# Altium schematic sheet 8051_IO.SchDoc(8051_IO)
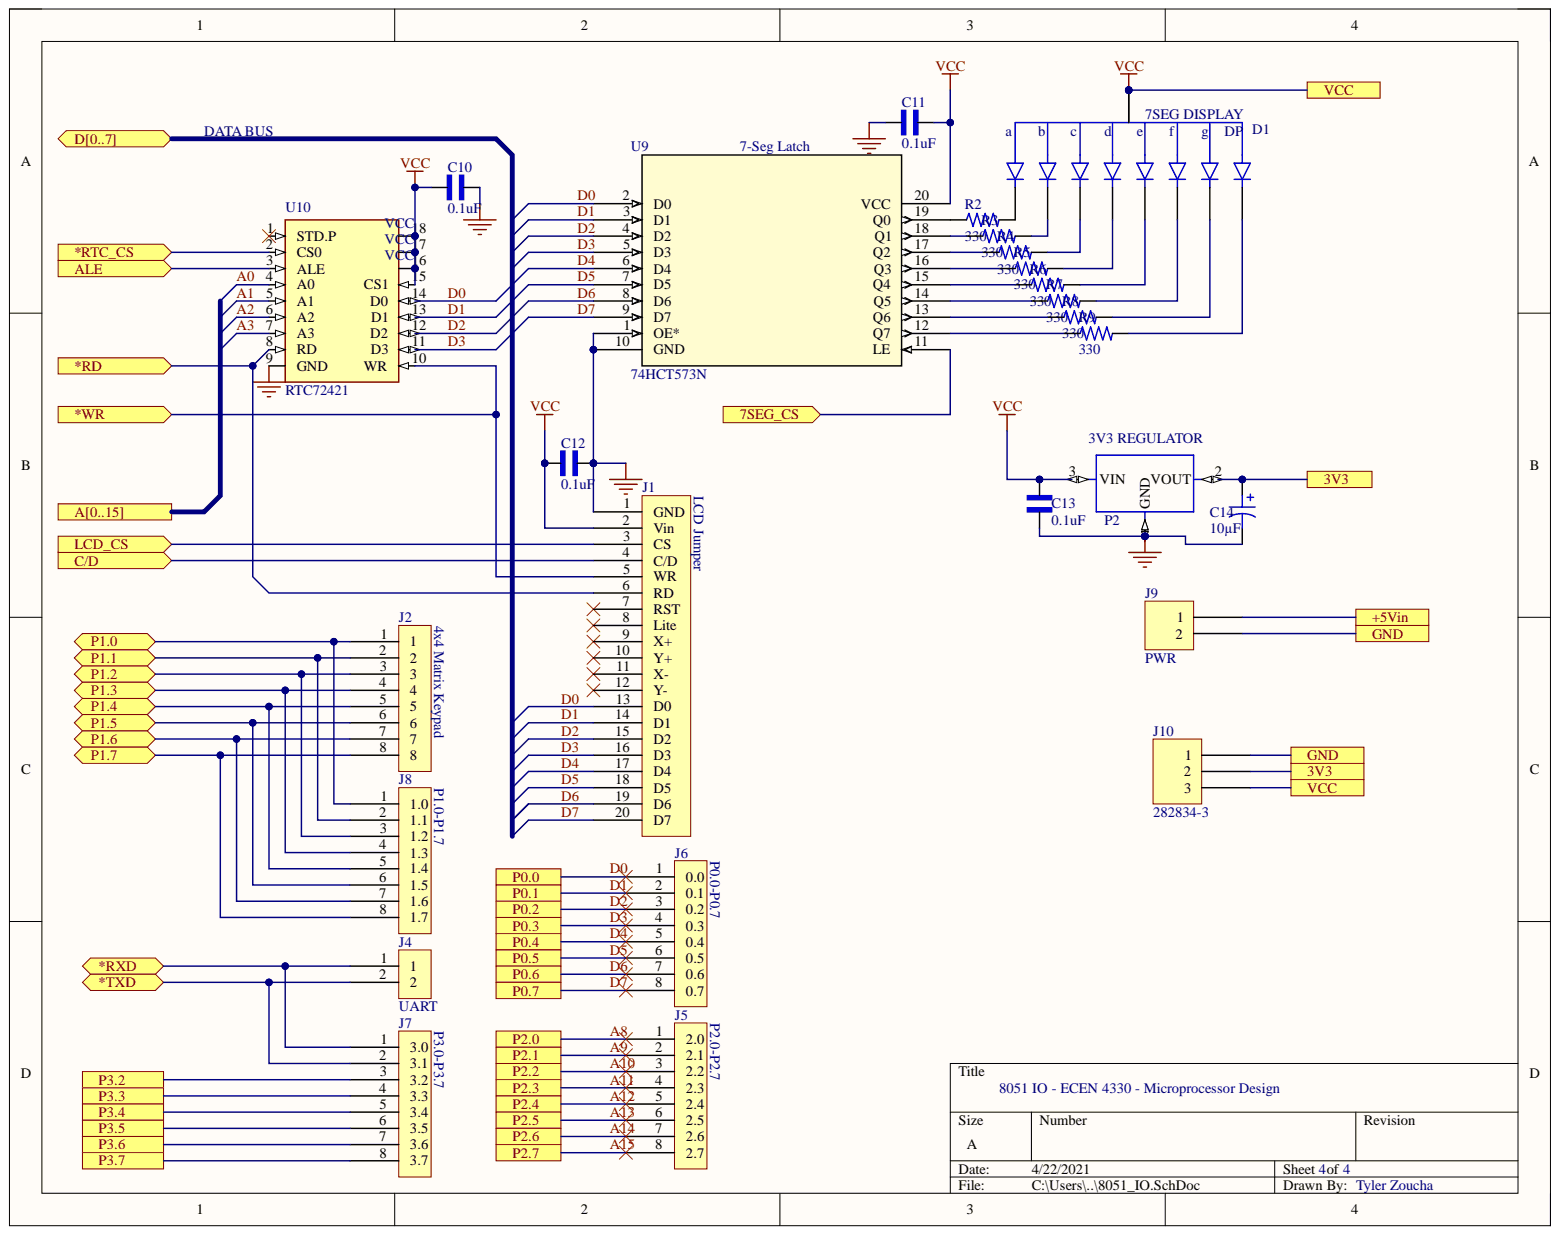
[diagram p0: 8051_IO.SchDoc]

## Parts
C10  0.1uF  [CAP_RDER73A681K2M1H03A]
C11  0.1uF  [CAP_RDER73A681K2M1H03A]
C12  0.1uF  [CAP_RDER73A681K2M1H03A]
C13  0.1uF  [CAP_RDER73A681K2M1H03A]
C14  10µF  [CAP TH ALUM ELEC D5.00mm S2.50mm H12.50mm]
D1  SA56-11SRWA  [DISPLAY_SA56-11SRWA]  (value not drawn)
J1  LCD Jumper  [20pinfemale_SIP]
J2  4x4 Matrix Keypad  [8pinheader_female]
J4  UART  [2pinheader_male]
J5  P2.0-P2.7  [8pinheader_female]
J6  P0.0-P0.7  [8pinheader_female]
J7  P3.0-P3.7  [8pinheader_female]
J8  P1.0-P1.7  [8pinheader_female]
J9  PWR  [TE 282834-2]
J10  282834-3  [TE 282834-3]
P2  PMIC-LD1117V33(TO-220)  [TO-220-3]  (value not drawn)
R2  330  [TO508P1060X500X1900-2]
R3  330  [TO508P1060X500X1900-2]
R4  330  [TO508P1060X500X1900-2]
R5  330  [TO508P1060X500X1900-2]
R6  330  [TO508P1060X500X1900-2]
R7  330  [TO508P1060X500X1900-2]
R8  330  [TO508P1060X500X1900-2]
R9  330  [TO508P1060X500X1900-2]
U9  74HCT573N  [SOT146-1_NEX]
U10  RTC72421  [DIL18]

## Ports
3V3, 7SEG_CS, *RD, *RTC_CS, *RXD, *TXD, *WR, +5Vin, A0, A1, A2, A3, A4, A5, A6, A7, A8, A9, A10, A11, A12, A13, A14, A15, A[0..15], ALE, C/D, D0, D1, D2, D3, D4, D5, D6, D7, D[0..7], GND, LCD_CS, P0.0, P0.1, P0.2, P0.3, P0.4, P0.5, P0.6, P0.7, P1.0, P1.1, P1.2, P1.3, P1.4, P1.5, P1.6, P1.7, P2.0, P2.1, P2.2, P2.3, P2.4, P2.5, P2.6, P2.7, P3.2, P3.3, P3.4, P3.5, P3.6, P3.7, VCC

## Nets
3V3: C14.1 J10.2 P2.2(VOUT) ; port 3V3
7SEG_CS: U9.11(LE) ; port 7SEG_CS
*RD: J1.6(RD) U10.8(RD) ; port *RD
*RTC_CS: U10.2(CS0) ; port *RTC_CS
*RXD: J4.1 J7.1(3.0) ; port *RXD
*TXD: J4.2 J7.2(3.1) ; port *TXD
*WR: J1.5(WR) U10.10(WR) ; port *WR
+5Vin: J9.1 ; port +5Vin
A0: U10.4(A0) ; port A[0..15]  [also labelled ]
A1: U10.5(A1) ; port A[0..15]  [also labelled ]
A2: U10.6(A2) ; port A[0..15]  [also labelled ]
A3: U10.7(A3) ; port A[0..15]  [also labelled ]
A8: J5.1(2.0) ; port P2.0
A9: J5.2(2.1) ; port P2.1
A10: J5.3(2.2) ; port P2.2
A11: J5.4(2.3) ; port P2.3
A12: J5.5(2.4) ; port P2.4
A13: J5.6(2.5) ; port P2.5
A14: J5.7(2.6) ; port P2.6
A15: J5.8(2.7) ; port P2.7
ALE: U10.3(ALE) ; port ALE
C/D: J1.4(C/D) ; port C/D
D0: J1.13(D0) J6.1(0.0) U9.2(D0) U10.14(D0) ; port D[0..7], port P0.0  [also labelled ]
D1: J1.14(D1) J6.2(0.1) U9.3(D1) U10.13(D1) ; port D[0..7], port P0.1  [also labelled ]
D2: J1.15(D2) J6.3(0.2) U9.4(D2) U10.12(D2) ; port D[0..7], port P0.2  [also labelled ]
D3: J1.16(D3) J6.4(0.3) U9.5(D3) U10.11(D3) ; port D[0..7], port P0.3  [also labelled ]
D4: J1.17(D4) J6.5(0.4) U9.6(D4) ; port D[0..7], port P0.4  [also labelled ]
D5: J1.18(D5) J6.6(0.5) U9.7(D5) ; port D[0..7], port P0.5  [also labelled ]
D6: J1.19(D6) J6.7(0.6) U9.8(D6) ; port D[0..7], port P0.6  [also labelled ]
D7: J1.20(D7) J6.8(0.7) U9.9(D7) ; port D[0..7], port P0.7  [also labelled ]
GND: J9.2 J10.1 ; port GND
LCD_CS: J1.3(CS) ; port LCD_CS
P1.0: J2.1 J8.1(1.0) ; port P1.0
P1.1: J2.2 J8.2(1.1) ; port P1.1
P1.2: J2.3 J8.3(1.2) ; port P1.2
P1.3: J2.4 J8.4(1.3) ; port P1.3
P1.4: J2.5 J8.5(1.4) ; port P1.4
P1.5: J2.6 J8.6(1.5) ; port P1.5
P1.6: J2.7 J8.7(1.6) ; port P1.6
P1.7: J2.8 J8.8(1.7) ; port P1.7
P3.2: J7.3(3.2) ; port P3.2
P3.3: J7.4(3.3) ; port P3.3
P3.4: J7.5(3.4) ; port P3.4
P3.5: J7.6(3.5) ; port P3.5
P3.6: J7.7(3.6) ; port P3.6
P3.7: J7.8(3.7) ; port P3.7
VCC: C10.1 C11.2 C12.1 C13.2 D1.3 D1.8 J1.2(Vin) J10.3 P2.3(VIN) U9.20(VCC) U10.15(CS1) U10.16 U10.17 U10.18 ; port VCC
NetC10_2: C10.2 C11.1 C12.2 C13.1 C14.2 J1.1(GND) P2.1(GND) P2.HEAT U9.1(OE*) U9.10(GND) U10.9(GND)
NetD1_1: D1.1 R6.2
NetD1_2: D1.2 R5.2
NetD1_4: D1.4 R4.2
NetD1_5: D1.5 R9.2
NetD1_6: D1.6 R3.2
NetD1_7: D1.7 R2.2
NetD1_9: D1.9 R7.2
NetD1_10: D1.10 R8.2
NetR2_1: R2.1 U9.19(Q0)
NetR3_1: R3.1 U9.18(Q1)
NetR4_1: R4.1 U9.17(Q2)
NetR5_1: R5.1 U9.16(Q3)
NetR6_1: R6.1 U9.15(Q4)
NetR7_1: R7.1 U9.14(Q5)
NetR8_1: R8.1 U9.13(Q6)
NetR9_1: R9.1 U9.12(Q7)
N00001_4: ; port A[0..15]
N00001_5: ; port A[0..15]
N00001_6: ; port A[0..15]
N00001_7: ; port A[0..15]
N00001_8: ; port A[0..15]
N00001_9: ; port A[0..15]
N00001_10: ; port A[0..15]
N00001_11: ; port A[0..15]
N00001_12: ; port A[0..15]
N00001_13: ; port A[0..15]
N00001_14: ; port A[0..15]
N00001_15: ; port A[0..15]

Not connected: J1 7(RST) 8(Lite) 9(X+) 10(Y+) 11(X-) 12(Y-); U10 1(STD.P)

## Library data of the parts
C10-C13 = RDER73A681K2M1H03A: Lead capacitor X7R(EIA) with Capacitance 680pF, Rated Voltage 1000Vdc; MF: Murata; Arrow_Electronics-Purchase-URL: https://snapeda.com/shop?store=Arrow+Electronics&id=4546400; Availability: Good; Check_prices: https://www.snapeda.com/parts/RDER73A681K2M1H03A/Murata/view-part/4546400/?ref=eda; Component Kind: Standard; Library Name: leadCapacitor.SchLib; Mouser-Purchase-URL: https://snapeda.com/shop?store=Mouser&id=4546400; MP: RDER73A681K2M1H03A; Package: Radial Murata; Price: None; SnapEDA_Link: https://www.snapeda.com/parts/RDER73A681K2M1H03A/Murata/view-part/4546400/?ref=snap
C14 = UKT1E100MDD1TD: CAP ALUM 10UF 20% 25V RADIAL; Manufacturer: Nichicon; Database Table Name: Capacitors - Aluminum - Electrolytic TH; Lifetime @ Temp.: 1000 Hrs @ 105°C; Ripple Current @ Low Frequency: 36mA @ 120Hz; Applications: Audio; Capacitance: 10µF; Component Kind: Standard; ComponentLink1Description: Datasheet; ComponentLink1URL: http://nichicon-us.com/english/products/pdfs/e-ukt.pdf; ComponentLink2Description: DigiKey Link; ComponentLink2URL: http://digikey.com/product-detail/en/nichicon/UKT1E100MDD1TD/493-10643-1-ND/4317658; Device Package: Radial, Can; Height - Seated (Max): 0.492" (12.50mm); LastUpdated: 9/4/2020 11:29:08 PM; Lead Spacing: 0.098" (2.50mm); Library Name: Celestial Altium Library - altium_library.DbLib; Lifecycle Status: Active; Minimum Order: 1; Mounting Type: Through Hole; Operating Temperature: -55°C ~ 105°C; Package / Case: Radial, Can; Packaging: TapeAndBox; Part Number: UKT1E100MDD1TD; Part Status: Active; PartId: 22914; Price: 0.08; Series: UKT; Size / Dimension: 0.197" Dia (5.00mm); Supplier 1: DigiKey; Supplier Part Number 1: 493-10643-1-ND; Tolerance: ±20%; Value: 10µF; Voltage - Rated: 25V
D1 = SA56-11SRWA: Display Modules - LED Character and Numeric Red 7-Segment 1 Character Common Anode 1.85V 20mA 0.750 H x 0.500 W x 0.315 D _19.05mm x 12.70mm x 8.00mm_ 10-DIP _0.600, 15.24mm_; MF: Kingbright; Availability: Unavailable; Component Kind: Standard; Library Name: 7seg_display.SchLib; MP: SA56-11SRWA; Package: DIP-10 Kingbright; Price: None; SnapEDA_Link: https://www.snapeda.com/parts/SA56-11SRWA/Kingbright/view-part/132432/?ref=snap
J2 J5-J8 = 8pinheader_female: Component Kind: Standard; Library Name: 8pinheader_female.SchLib
J4 = 2pin_header: Component Kind: Standard; Library Name: 2pinheader.SchLib
J9 = 282834-2: TERM BLK 2P SIDE ENT 2.54MM PCB; Manufacturer: TE Connectivity AMP Connectors; Current: 10A; Database Table Name: Connectors - Terminal Blocks - Wire to Board; Mating Orientation: Horizontal with Board; Number of Levels: 1; Pitch: 0.100" (2.54mm); Positions Per Level: 2; Voltage: 150V; Wire Gauge: 16-30 AWG; Wire Termination: Screw - Rising Cage Clamp; Color: Green; Component Kind: Standard; ComponentLink1Description: Datasheet; ComponentLink1URL: https://www.te.com/commerce/DocumentDelivery/DDEController?Action=srchrtrv&DocNm=282834&DocType=Customer+Drawing&DocLang=English; ComponentLink2Description: DigiKey Link; ComponentLink2URL: http://digikey.com/product-detail/en/te-connectivity-amp-connectors/282834-2/A98333-ND/1150135; LastUpdated: 1/15/2020 4:15:38 AM; Library Name: Celestial Altium Library - altium_library.DbLib; Lifecycle Status: Active; Minimum Order: 1; Mounting Type: Through Hole; Packaging: Bulk; Part Number: 282834-2; Part Status: Active; Price: 0.88; Series: Buchanan; Supplier 1: DigiKey; Supplier Part Number 1: A98333-ND
J10 = 282834-3: TERM BLK 3P SIDE ENT 2.54MM PCB; Manufacturer: TE Connectivity AMP Connectors; Current: 10A; Database Table Name: Connectors - Terminal Blocks - Wire to Board; Mating Orientation: Horizontal with Board; Number of Levels: 1; Pitch: 0.100" (2.54mm); Positions Per Level: 3; Voltage: 150V; Wire Gauge: 16-30 AWG; Wire Termination: Screw - Rising Cage Clamp; Color: Green; Component Kind: Standard; ComponentLink1Description: Datasheet; ComponentLink1URL: https://www.te.com/commerce/DocumentDelivery/DDEController?Action=srchrtrv&DocNm=282834&DocType=Customer+Drawing&DocLang=English; ComponentLink2Description: DigiKey Link; ComponentLink2URL: http://digikey.com/product-detail/en/te-connectivity-amp-connectors/282834-3/A98334-ND/1153264; LastUpdated: 1/15/2020 4:15:50 AM; Library Name: Celestial Altium Library - altium_library.DbLib; Lifecycle Status: Active; Minimum Order: 1; Mounting Type: Through Hole; Packaging: Bulk; Part Number: 282834-3; Part Status: Active; Price: 2.01; Series: Buchanan; Supplier 1: DigiKey; Supplier Part Number 1: A98334-ND
P2 = PMIC-LD1117V33(TO-220): Linear Voltage Regulator IC 1 Output 800mA TO-220AB; MF: STMicroelectronics; Availability: Unavailable; Component Kind: Standard; Library Name: LD117V33_voltageRegulator.SchLib; MP: LD1117V33; Package: TO-220 STMicroelectronics; Price: None; SnapEDA_Link: https://www.snapeda.com/parts/LD1117V33/STMicroelectronics/view-part/51339/?ref=snap
R2-R9 = 1-1623809-3: MPR20C 22R 5%; Availability: Unavailable; Component Kind: Standard; Library Name: RESISTOR_330.SchLib; MP: 1-1623809-3; Package: None; Price: None
U9 = 74HCT573N: No Description Available; SourceLibrary: Nexperia_2018-05-2018; Vendor: Nexperia; Component Kind: Standard; Library Name: 74HCT573N.SchLib; Manufacturer_Part_Number: 74HCT573N; RefDes: RefDes; Type: DEV; Copyright: Copyright (C) 2016 Accelerated Designs. All rights reserved
U10 = RTC72421: <b>Real Time Clock</b><p> http://www.foxonline.com/72421.htm; Availability: Unavailable; Component Kind: Standard; Library Name: RTC72421.SchLib; MP: RTC72421; Package: None; Price: None
J1 = LCD Jumper: Component Kind: Standard; Library Name: LCD_Jumper.SchLib
